# Altium schematic sheet 28Pins_Schematic_V1I1.SchDoc(28Pins_Schematic_V1I1)
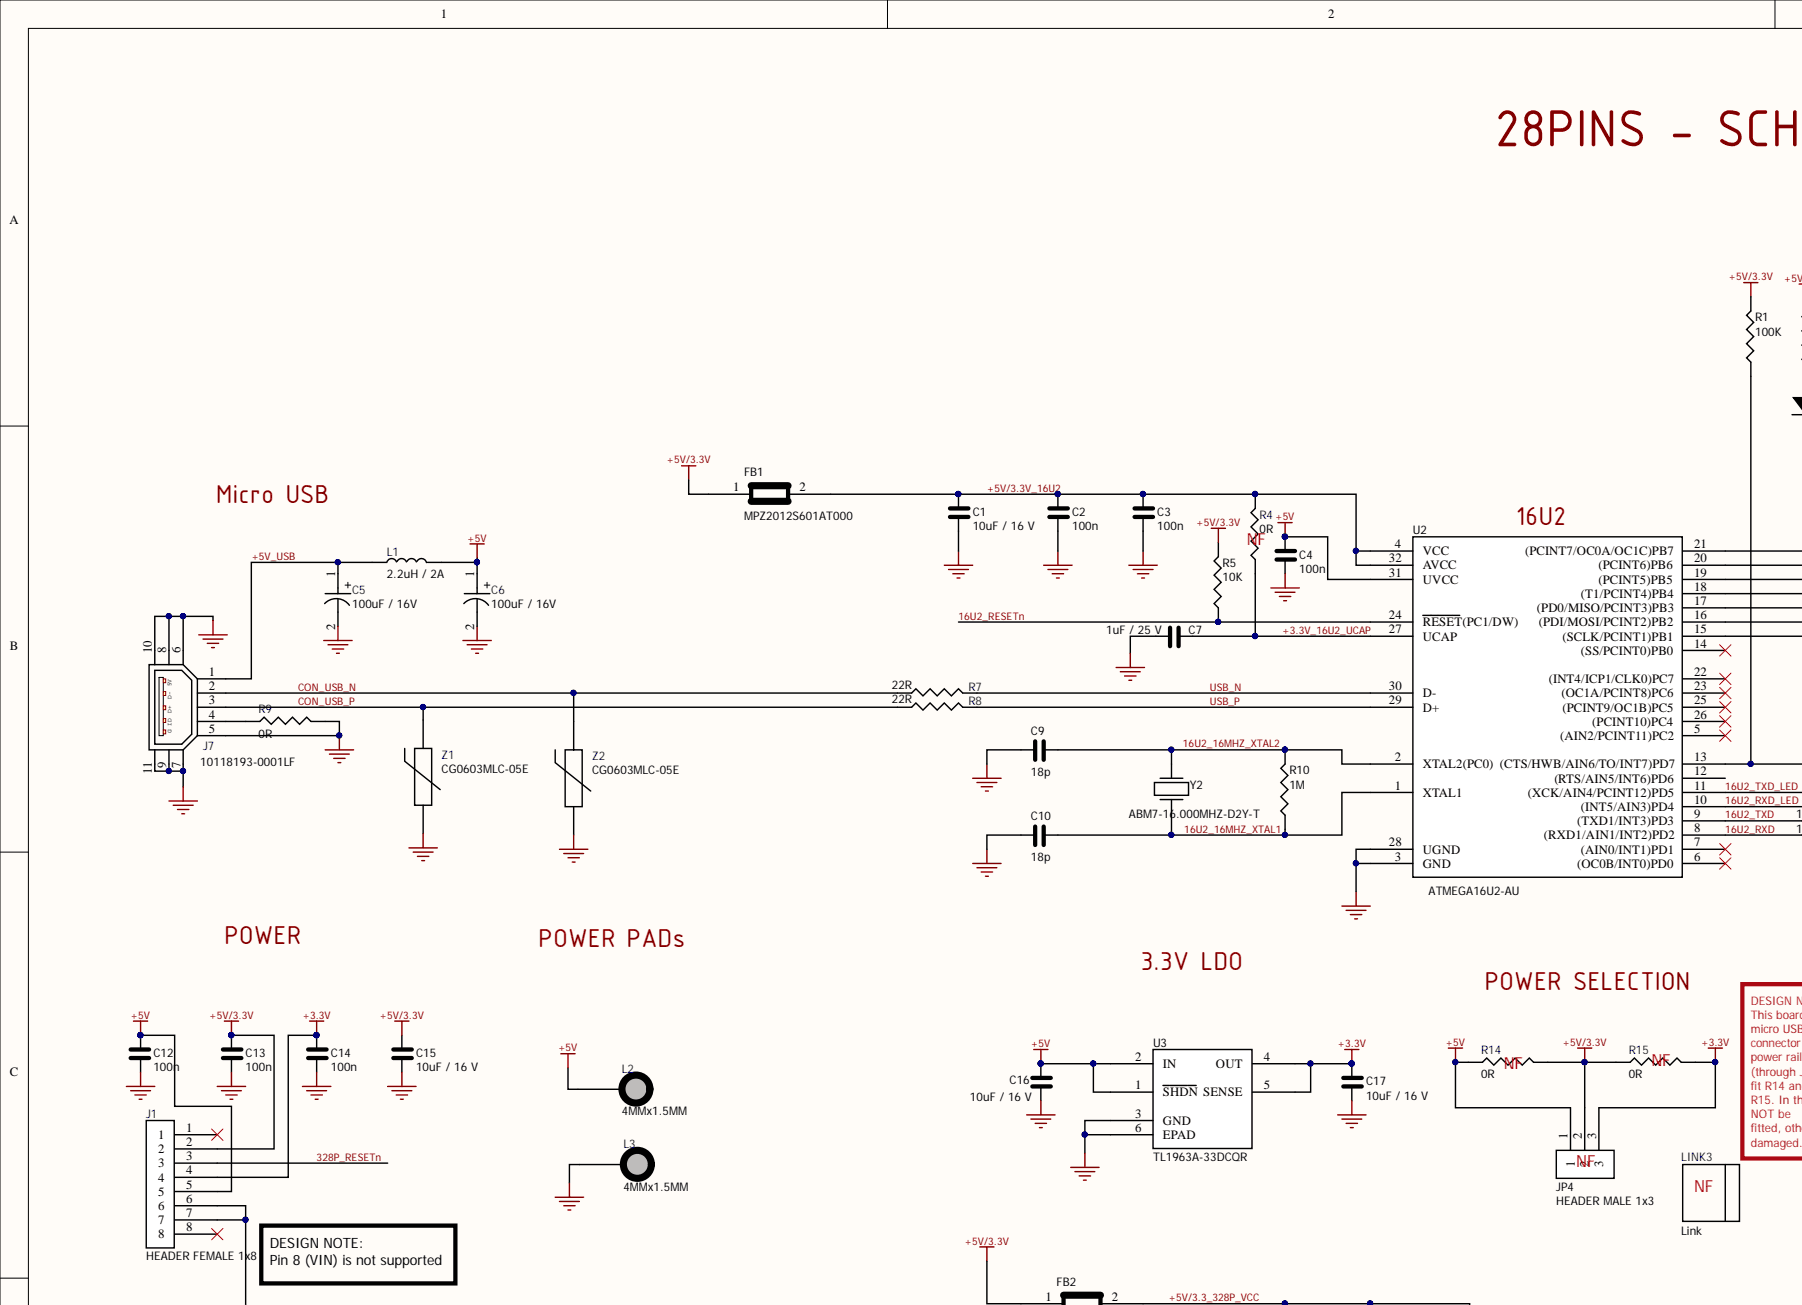
[diagram p0: 28Pins_Schematic_V1I1.SchDoc, top left]
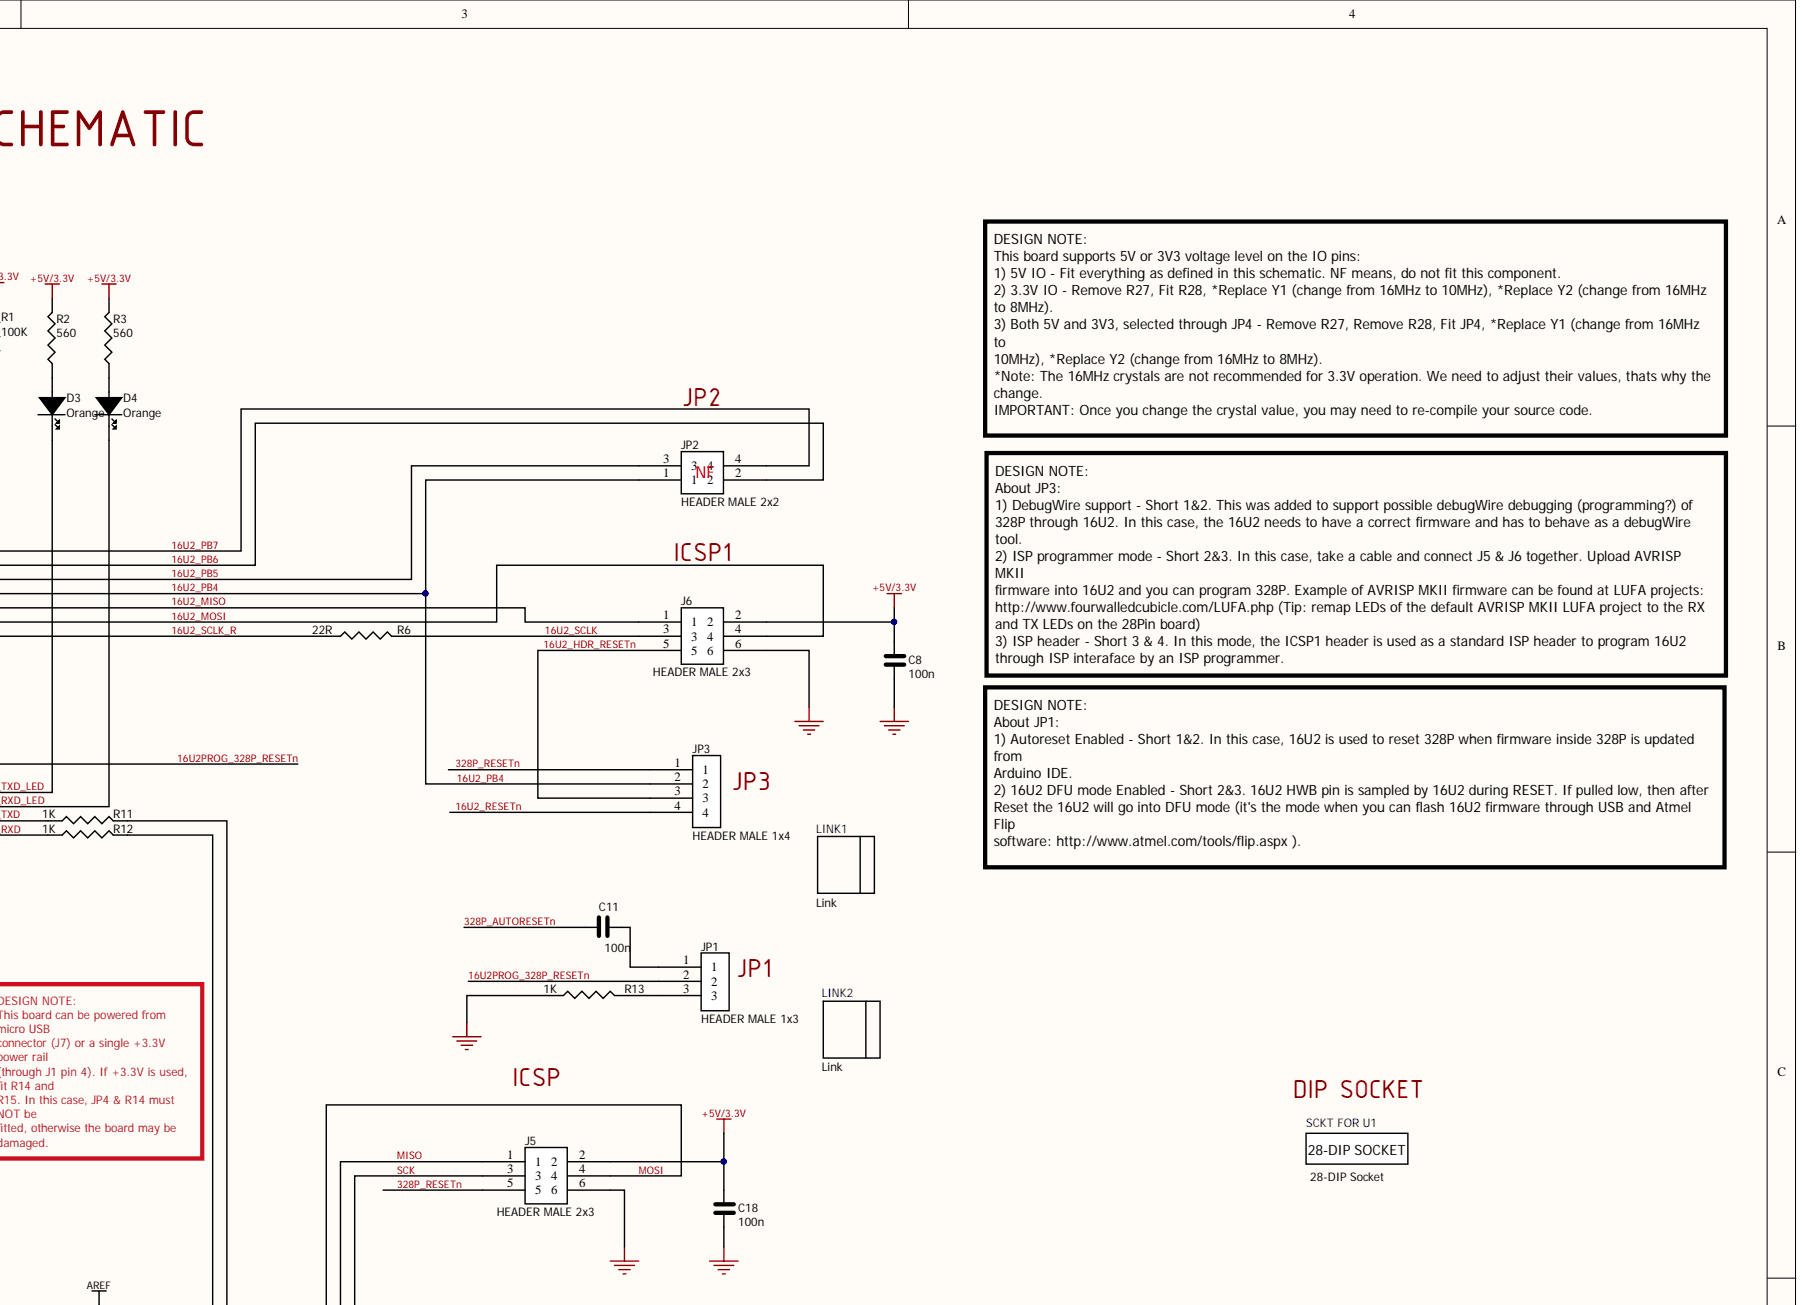
[diagram p1: 28Pins_Schematic_V1I1.SchDoc, top right]
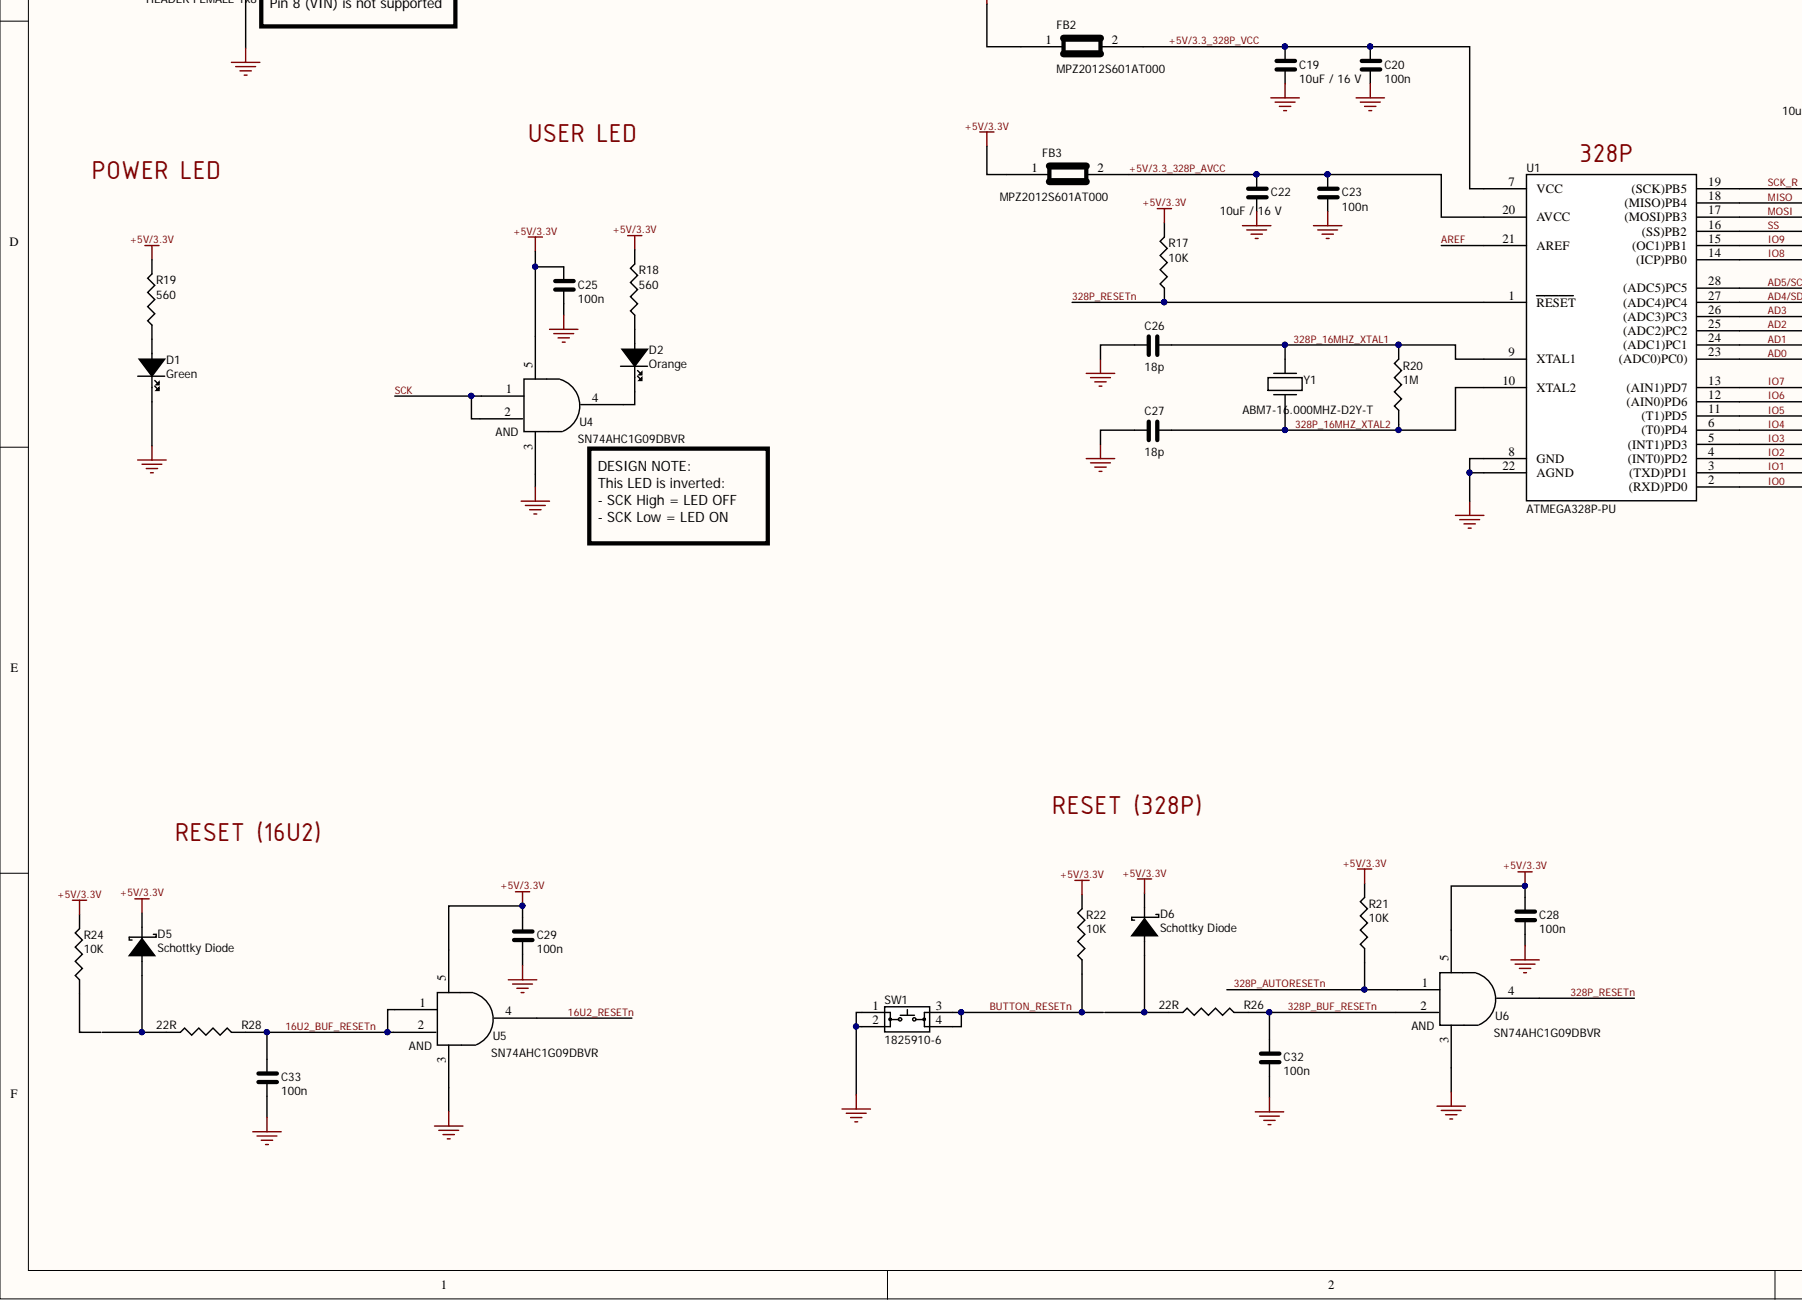
[diagram p2: 28Pins_Schematic_V1I1.SchDoc, bottom left]
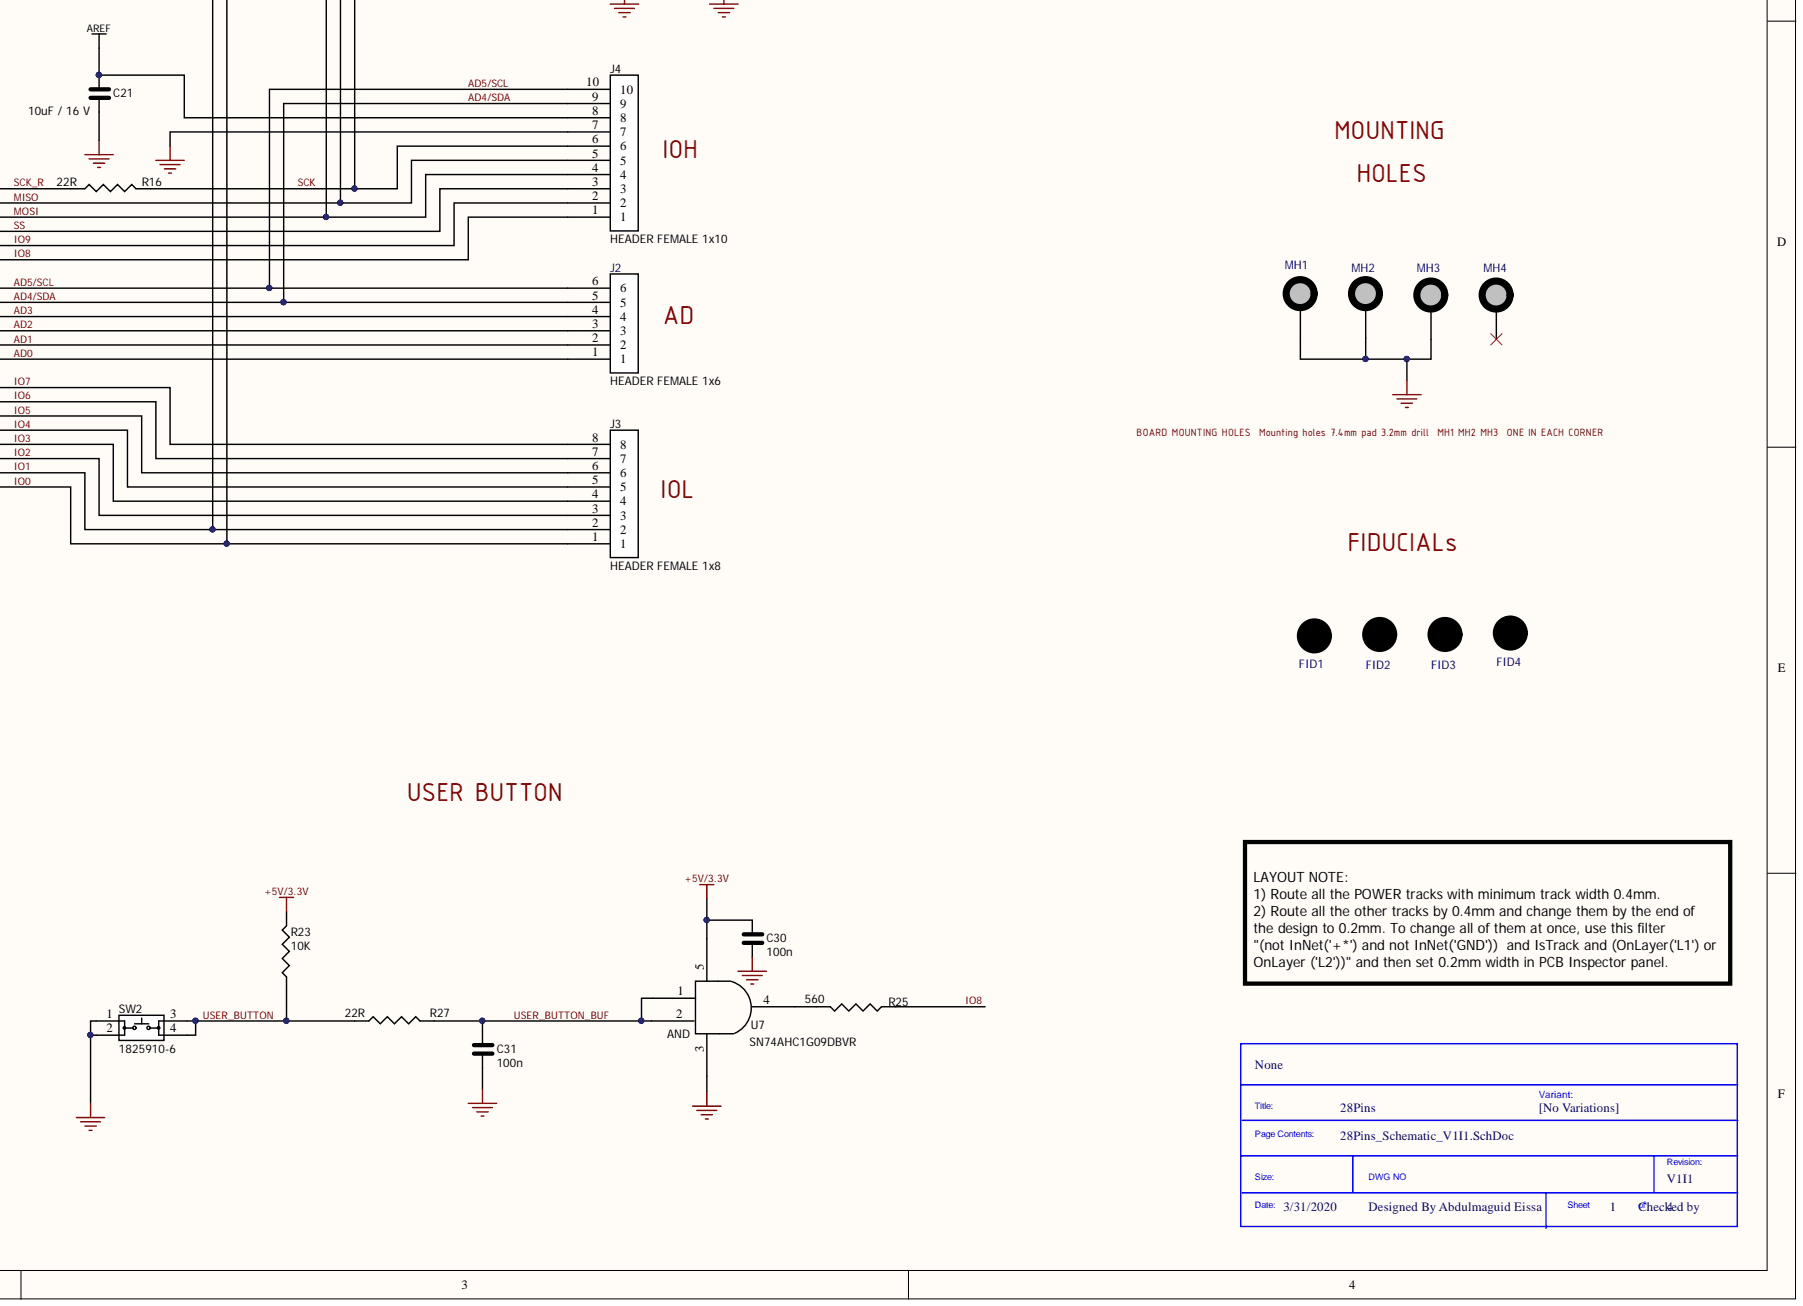
[diagram p3: 28Pins_Schematic_V1I1.SchDoc, bottom right]

## Parts
C1  10uF / 16 V  [C0805]
C2  100n  [C0805]
C3  100n  [C0805]
C4  100n  [C0805]
C5  100uF / 16V  [C_ALU_C_SIZE]
C6  100uF / 16V  [C_ALU_C_SIZE]
C7  1uF / 25 V  [C0805]
C8  100n  [C0805]
C9  18p  [C0805]
C10  18p  [C0805]
C11  100n  [C0805]
C12  100n  [C0805]
C13  100n  [C0805]
C14  100n  [C0805]
C15  10uF / 16 V  [C0805]
C16  10uF / 16 V  [C0805]
C17  10uF / 16 V  [C0805]
C18  100n  [C0805]
C19  10uF / 16 V  [C0805]
C20  100n  [C0805]
C21  10uF / 16 V  [C0805]
C22  10uF / 16 V  [C0805]
C23  100n  [C0805]
C25  100n  [C0805]
C26  18p  [C0805]
C27  18p  [C0805]
C28  100n  [C0805]
C29  100n  [C0805]
C30  100n  [C0805]
C31  100n  [C0805]
C32  100n  [C0805]
C33  100n  [C0805]
D1  Green  [LED0603]
D2  Orange  [LED0603]
D3  Orange  [LED0603]
D4  Orange  [LED0603]
D5  Schottky Diode  [SOD323]
D6  Schottky Diode  [SOD323]
FB1  MPZ2012S601AT000  [C0805]
FB2  MPZ2012S601AT000  [C0805]
FB3  MPZ2012S601AT000  [C0805]
FID1  FID_1mm  [FID_40x1120]  (value not drawn)  (no BOM)
FID2  FID_1mm  [FID_40x1120]  (value not drawn)  (no BOM)
FID3  FID_1mm  [FID_40x1120]  (value not drawn)  (no BOM)
FID4  FID_1mm  [FID_40x1120]  (value not drawn)  (no BOM)
J1  HEADER FEMALE 1x8  [1x8PIN_FEMALE_HDR]
J2  HEADER FEMALE 1x6  [1x6PIN_FEMALE_HDR]
J3  HEADER FEMALE 1x8  [1x8PIN_FEMALE_HDR]
J4  HEADER FEMALE 1x10  [1x10PIN_FEMALE_HDR]
J5  HEADER MALE 2x3  [2x3PIN_MALE_HDR]
J6  HEADER MALE 2x3  [2x3PIN_MALE_HDR]
J7  10118193-0001LF  [USB_SOP]
JP1  HEADER MALE 1x3  [1x3PIN_MALE_HDR]
JP2  HEADER MALE 2x2  [2x2PIN_MALE_HDR]
JP3  HEADER MALE 1x4  [1x4PIN_MALE_HDR]
JP4  HEADER MALE 1x3  [1x3PIN_MALE_HDR]
L1  2.2uH / 2A  [L1210]
L2  4MMx1.5MM  [PADS_WITH_WHOLE_4mmx1.5mm]  (no BOM)
L3  4MMx1.5MM  [PADS_WITH_WHOLE_4mmx1.5mm]  (no BOM)
LINK1  Link  (mechanical)
LINK2  Link  (mechanical)
LINK3  Link  (mechanical)
MH1  Mounting Whole 3.4mmx3.2mm  [MOUNTING_WHOLE_NON_COPPER]  (value not drawn)  (no BOM)
MH2  Mounting Whole 3.4mmx3.2mm  [MOUNTING_WHOLE_NON_COPPER]  (value not drawn)  (no BOM)
MH3  Mounting Whole 3.4mmx3.2mm  [MOUNTING_WHOLE_NON_COPPER]  (value not drawn)  (no BOM)
MH4  Mounting Whole 3.4mmx3.2mm  [MOUNTING_WHOLE_NON_COPPER]  (value not drawn)  (no BOM)
R1  100K  [R0805]
R2  560  [R0805]
R3  560  [R0805]
R4  0R  [R0805]
R5  10K  [R0805]
R6  22R  [R0805]
R7  22R  [R0805]
R8  22R  [R0805]
R9  0R  [R0805]
R10  1M  [R0805]
R11  1K  [R0805]
R12  1K  [R0805]
R13  1K  [R0805]
R14  0R  [R0805]
R15  0R  [R0805]
R16  22R  [R0805]
R17  10K  [R0805]
R18  560  [R0805]
R19  560  [R0805]
R20  1M  [R0805]
R21  10K  [R0805]
R22  10K  [R0805]
R23  10K  [R0805]
R24  10K  [R0805]
R25  560  [R0805]
R26  22R  [R0805]
R27  22R  [R0805]
R28  22R  [R0805]
SCKT_FOR_U1  28-DIP Socket  [28-DIP_SCKT]
SW1  1825910-6  [4PIN_BUTTON]
SW2  1825910-6  [4PIN_BUTTON]
U1  ATMEGA328P-PU  [28_DIP_U1]
U2  ATMEGA16U2-AU  [TQFP32]
U3  TL1963A-33DCQR  [DCQR R-PDSO-G6]
U4  SN74AHC1G09DBVR  [DBV_SOP_23_5]
U5  SN74AHC1G09DBVR  [DBV_SOP_23_5]
U6  SN74AHC1G09DBVR  [DBV_SOP_23_5]
U7  SN74AHC1G09DBVR  [DBV_SOP_23_5]
Y1  ABM7-16.000MHZ-D2Y-T  [AMB7_V1]
Y2  ABM7-16.000MHZ-D2Y-T  [AMB7_V1]
Z1  CG0603MLC-05E  [R0603]
Z2  CG0603MLC-05E  [R0603]

## Nets
+3.3V: C14.2 C17.2 J1.4 JP4.3 R15.2 U3.4(OUT) U3.5(SENSE)
+5V: C4.2 C6.1 C12.1 C16.2 J1.5 JP4.1 L1.2 L2.1 R14.1 U2.31(UVCC) U3.1(SHDN) U3.2(IN)
+5V/3.3V: C8.2 C13.2 C15.2 C18.2 C25.2 C28.2 C29.2 C30.2 D5.1 D6.1 FB1.1 FB2.1 FB3.1 J1.2 J5.2 J6.2 JP4.2 R1.2 R2.2 R3.2 R5.2 R14.2 R15.1 R17.2 R18.2 R19.2 R21.2 R22.2 R23.2 R24.2 U4.5 U5.5 U6.5 U7.5
GND: C1.1 C2.1 C3.1 C4.1 C5.2 C6.2 C7.1 C8.1 C9.1 C10.1 C12.2 C13.1 C14.1 C15.1 C16.1 C17.1 C18.1 C19.1 C20.1 C21.1 C22.1 C23.1 C25.1 C26.1 C27.1 C28.1 C29.1 C30.1 C31.1 C32.1 C33.1 D1.1 J1.6 J1.7 J4.7 J5.6 J6.6 J7.5 J7.6 J7.7 J7.8(5V) J7.9 J7.10 J7.11 L3.1 MH1.1 MH2.1 MH3.1 R9.2 R13.1 SW1.1 SW1.2 SW2.1 SW2.2 U1.8(GND) U1.22(AGND) U2.3(GND) U2.28(UGND) U3.3(GND) U3.6(EPAD) U4.3 U5.3 U6.3 U7.3 Z1.1 Z2.1
16U2_16MHZ_XTAL1: C10.2 R10.1 U2.1(XTAL1) Y2.1
16U2_16MHZ_XTAL2: C9.2 R10.2 U2.2(XTAL2(PC0)) Y2.2
16U2_BUF_RESETN: C33.2 R28.2 U5.1 U5.2
16U2_HDR_RESETN: J6.5 JP3.3
16U2_MISO: J6.1 U2.17((PD0/MISO/PCINT3)PB3)
16U2_MOSI: J6.4 U2.16((PDI/MOSI/PCINT2)PB2)
16U2_PB4: JP2.1 JP3.2 U2.18((T1/PCINT4)PB4)
16U2_PB5: JP2.3 U2.19((PCINT5)PB5)
16U2_PB6: JP2.2(NF) U2.20((PCINT6)PB6)
16U2_PB7: JP2.4 U2.21((PCINT7/OC0A/OC1C)PB7)
16U2_RESETN: JP3.4 R5.1 U2.24(RESET(PC1/DW)) U5.4
16U2_RXD: R12.1 U2.8((RXD1/AIN1/INT2)PD2)
16U2_RXD_LED: D4.1 U2.10((INT5/AIN3)PD4)
16U2_SCLK: J6.3 R6.2
16U2_SCLK_R: R6.1 U2.15((SCLK/PCINT1)PB1)
16U2_TXD: R11.1 U2.9((TXD1/INT3)PD3)
16U2_TXD_LED: D3.1 U2.11((XCK/AIN4/PCINT12)PD5)
16U2PROG_328P_RESETN: JP1.2 R1.1 U2.13((CTS/HWB/AIN6/TO/INT7)PD7)
328P_16MHZ_XTAL1: C26.2 R20.2 U1.9(XTAL1) Y1.2
328P_16MHZ_XTAL2: C27.2 R20.1 U1.10(XTAL2) Y1.1
328P_AUTORESETN: C11.2 R21.1 U6.1
328P_BUF_RESETN: C32.2 R26.2 U6.2
328P_RESETN: J1.3 J5.5 JP3.1 R17.1 U1.1(RESET) U6.4
+3.3V_16U2_UCAP: C7.2 R4.1 U2.27(UCAP)
+5V/3.3_328P_AVCC: C22.2 C23.2 FB3.2 U1.20(AVCC)
+5V/3.3_328P_VCC: C19.2 C20.2 FB2.2 U1.7(VCC)
+5V/3.3V_16U2: C1.2 C2.2 C3.2 FB1.2 R4.2 U2.4(VCC) U2.32(AVCC)
+5V_USB: C5.1 J7.1 L1.1
AD0: J2.1 U1.23((ADC0)PC0))
AD1: J2.2 U1.24((ADC1)PC1)
AD2: J2.3 U1.25((ADC2)PC2)
AD3: J2.4 U1.26((ADC3)PC3)
AD4/SDA: J2.5 J4.9 U1.27((ADC4)PC4)
AD5/SCL: J2.6 J4.10 U1.28((ADC5)PC5)
AREF: C21.2 J4.8 U1.21(AREF)
BUTTON_RESETN: R22.1 SW1.3 SW1.4
CON_USB_N: J7.2 R7.1 Z2.2
CON_USB_P: J7.3 R8.1 Z1.2
IO0: J3.1 R11.2 U1.2((RXD)PD0)
IO1: J3.2 R12.2 U1.3((TXD)PD1)
IO2: J3.3 U1.4((INT0)PD2)
IO3: J3.4 U1.5((INT1)PD3)
IO4: J3.5 U1.6((T0)PD4)
IO5: J3.6 U1.11((T1)PD5)
IO6: J3.7 U1.12((AIN0)PD6)
IO7: J3.8 U1.13((AIN1)PD7)
IO8: J4.1 R25.1 U1.14((ICP)PB0)
IO9: J4.2 U1.15((OC1)PB1)
MISO: J4.5 J5.1 U1.18((MISO)PB4)
MOSI: J4.4 J5.4 U1.17((MOSI)PB3)
SCK: J4.6 J5.3 R16.2 U4.1 U4.2
SCK_R: R16.1 U1.19((SCK)PB5)
SS: J4.3 U1.16((SS)PB2)
USB_N: R7.2 U2.30(D-)
USB_P: R8.2 U2.29(D+)
USER_BUTTON: R23.1 R27.1 SW2.3 SW2.4
USER_BUTTON_BUF: C31.2 R27.2 U7.1 U7.2
NetC11_1: C11.1 JP1.1
NetD1_2: D1.2 R19.1
NetD2_1: D2.1 U4.4
NetD2_2: D2.2 R18.1
NetD3_2: D3.2 R2.1
NetD4_2: D4.2 R3.1
NetD5_2: D5.2 R28.1
NetD6_2: D6.2 R26.1
NetJ7_4: J7.4 R9.1
NetJP1_3: JP1.3 R13.2
NetR25_2: R25.2 U7.4

Not connected: J1 1 8; MH4 1; R24 1; U2 5((AIN2/PCINT11)PC2) 6((OC0B/INT0)PD0) 7((AIN0/INT1)PD1) 12((RTS/AIN5/INT6)PD6) 14((SS/PCINT0)PB0) 22((INT4/ICP1/CLK0)PC7) 23((OC1A/PCINT8)PC6) 25((PCINT9/OC1B)PC5) 26((PCINT10)PC4)

## Library data of the parts
C1 C15-C17 C19 C21 C22 = 10uF_CAP: Component Kind: Standard; Library Name: 28Pins_Schematic_Lib.SchLib
C2-C4 C8 C11-C14 C18 C20 C23 C25 C28-C33 = 0.1uF_CAP: Component Kind: Standard; Library Name: 28Pins_Schematic_Lib.SchLib
C5 C6 = 100uF_PCAP: Component Kind: Standard; Library Name: 28Pins_Schematic_Lib.SchLib
C7 = 1uF_CAP: Component Kind: Standard; Library Name: 28Pins_Schematic_Lib.SchLib
C9 C10 C26 C27 = 18pF_CAP: Component Kind: Standard; Library Name: 28Pins_Schematic_Lib.SchLib
D1 = LED_Green: Component Kind: Standard; Library Name: 28Pins_Schematic_Lib.SchLib
D2-D4 = LED_Orange: Component Kind: Standard; Library Name: 28Pins_Schematic_Lib.SchLib
D5 D6 = Schottky Diode: Diode,Schottky Barrier,Fast,Vr 30V,If 200mA,Pkg SOD-323,Vf 0.52VDC,Trr 5ns; Manufacturer: ON Semiconductor; Manufacturer Part Number: BAT54HT1G; Component Kind: Standard; ComponentLink1Description: https://www.alliedelec.com/m/d/32ed7fc37a0b4f97ae8b34bd526f7e4f.pdf; ComponentLink1URL: https://www.alliedelec.com/m/d/32ed7fc37a0b4f97ae8b34bd526f7e4f.pdf; ComponentLink2Description: https://www.alliedelec.com/onsemiconductor-bat54ht1g/70099482/; ComponentLink2URL: https://www.alliedelec.com/onsemiconductor-bat54ht1g/70099482/; Library Name: 28Pins_Schematic_Lib.SchLib; Pricing 1: 10=0.0946, 100=0.0936, 250=0.0927 (USD); RoHS: No; Stock 1: 4340; Supplier 1: Allied; Supplier Part Number 1: 70099482
FB1-FB3 = Ferrite_Bead: Component Kind: Standard; Library Name: 28Pins_Schematic_Lib.SchLib
FID1-FID4 = Fiducial: Fiducial 40mil diameter; Library Name: 28Pins_Schematic_Lib.SchLib
J1 J3 = 1x8Pin_Female_Hdr: Component Kind: Standard; Library Name: 28Pins_Schematic_Lib.SchLib
J2 = 1x6Pin_Female_Hdr: Component Kind: Standard; Library Name: 28Pins_Schematic_Lib.SchLib
J4 = 10Pin_Female_Hdr: Component Kind: Standard; Library Name: 28Pins_Schematic_Lib.SchLib
J5 J6 = 2x3Pin_Male_Hdr: Component Kind: Standard; Library Name: 28Pins_Schematic_Lib.SchLib
J7 = 10118193-0001LF: Right Angle Surface Mount Mount Female USB Connector 100 V ac 1.8A; Manufacturer: Amphenol ICC; Component Kind: Standard; ComponentLink1Description: https://www.alliedelec.com/m/d/e03afc8e486ea55a2b0205fb8203b916.pdf; ComponentLink1URL: https://www.alliedelec.com/m/d/e03afc8e486ea55a2b0205fb8203b916.pdf; ComponentLink2Description: https://www.alliedelec.com/amphenolicc-10118193-0001lf/70236827/; ComponentLink2URL: https://www.alliedelec.com/amphenolicc-10118193-0001lf/70236827/; Library Name: 28Pins_Schematic_Lib.SchLib; Manufacturer Part Number: 10118193-0001LF; Pricing 1: 1=0.2919, 25=0.2747, 100=0.2661, 250=0.2318, 500=0.2276 (USD); RoHS: No; Stock 1: 6540; Supplier 1: Allied; Supplier Part Number 1: 70236827
JP1 JP4 = 1x3Pin_Male_Hdr: Component Kind: Standard; Library Name: 28Pins_Schematic_Lib.SchLib
JP2 = 2x2Pin_Male_Hdr: Component Kind: Standard; Library Name: 28Pins_Schematic_Lib.SchLib
JP3 = 1x4Pin_Male_Hdr: Component Kind: Standard; Library Name: 28Pins_Schematic_Lib.SchLib
L1 = L1210R2R2MDWIT: Inductor; Component Kind: Standard; Library Name: 28Pins_Schematic_Lib.SchLib
L2 L3 = PAD_WITH_WHOLE_4MMx1.5MM: Library Name: 28Pins_Schematic_Lib.SchLib
LINK1-LINK3 = Link: CONN JUMPER; Library Name: 28Pins_Schematic_Lib.SchLib
MH1-MH4 = MOUNTING_WHOLE_NON_COPPER: Library Name: 28Pins_Schematic_Lib.SchLib
R1 = 100K_Resistor: Component Kind: Standard; Library Name: 28Pins_Schematic_Lib.SchLib
R2 R3 R18 R19 R25 = 560_Resistor: Component Kind: Standard; Library Name: 28Pins_Schematic_Lib.SchLib
R4 R9 R14 R15 = 0R_Resistor: Component Kind: Standard; Library Name: 28Pins_Schematic_Lib.SchLib
R5 R17 R21-R24 = 10K_Resistor: Component Kind: Standard; Library Name: 28Pins_Schematic_Lib.SchLib
R6-R8 R16 R26-R28 = 22_Resistor: Component Kind: Standard; Library Name: 28Pins_Schematic_Lib.SchLib
R10 R20 = 1M_Resistor: Component Kind: Standard; Library Name: 28Pins_Schematic_Lib.SchLib
R11-R13 = 1K_Resistor: Component Kind: Standard; Library Name: 28Pins_Schematic_Lib.SchLib
SCKT_FOR_U1 = 28Pin_DIP: Component Kind: Standard; Library Name: 28Pins_Schematic_Lib.SchLib
SW1 SW2 = 4Pin_Push_Button: Push_Button; Component Kind: Standard; Library Name: 28Pins_Schematic_Lib.SchLib
U1 = ATMEGA328P-PU: ATMEGA328P-PU; 8 bit Microcontroller AVR 20MHz 1; 32kb Flash; 2kb RAM; I2C SPI 2; Manufacturer: Microchip Technology Inc.; Component Kind: Standard; ComponentLink1Description: https://www.alliedelec.com/m/d/768ce6a229d36838fb8bd4dcb29bd26e.pdf; ComponentLink1URL: https://www.alliedelec.com/m/d/768ce6a229d36838fb8bd4dcb29bd26e.pdf; ComponentLink2Description: https://www.alliedelec.com/microchiptechnologyinc-atmega328p-pu/70332157/; ComponentLink2URL: https://www.alliedelec.com/microchiptechnologyinc-atmega328p-pu/70332157/; Library Name: 28Pins_Schematic_Lib.SchLib; Manufacturer Part Number: ATMEGA328P-PU; Pricing 1: 1=5.1200, 5=4.6100, 10=4.1800, 25=3.8300, 100=3.5500 (USD); RoHS: No; Stock 1: 0; Supplier 1: Allied; Supplier Part Number 1: 70332157
U3 = Regulator: Component Kind: Standard; Library Name: 28Pins_Schematic_Lib.SchLib
U4-U7 = AND gate: Component Kind: Standard; Library Name: 28Pins_Schematic_Lib.SchLib
Y1 Y2 = ABM7-16.000MHZ-D2Y-T: Crystal; Ceramic; 16.000MHZ; 18pf; 20ppm @ 25C; 30ppm -40+85C; 6.0 x 3.5 x 1.2mm; T/R; Manufacturer: Abracon; Component Kind: Standard; ComponentLink1Description: https://www.alliedelec.com; ComponentLink1URL: https://www.alliedelec.com; ComponentLink2Description: https://www.alliedelec.com/abracon-abm7-16-000mhz-d2y-t/71528095/; ComponentLink2URL: https://www.alliedelec.com/abracon-abm7-16-000mhz-d2y-t/71528095/; Library Name: 28Pins_Schematic_Lib.SchLib; Manufacturer Part Number: ABM7-16.000MHZ-D2Y-T; Pricing 1: 1000=0.5800 (USD); RoHS: No; Stock 1: 0; Supplier 1: Allied; Supplier Part Number 1: 71528095
Z1 Z2 = CG0603MLC-05E: Varistor; ESD Clamp Protector; 5 VDC; 20 Vclamp; IEC 61000-4-2 Level 4; 0603 SMD; Manufacturer: Bourns; Component Kind: Standard; ComponentLink1Description: https://www.alliedelec.com/m/d/c74d21cd372fe06fa9c5b8b4ec8a7246.pdf; ComponentLink1URL: https://www.alliedelec.com/m/d/c74d21cd372fe06fa9c5b8b4ec8a7246.pdf; ComponentLink2Description: https://www.alliedelec.com/bourns-cg0603mlc-05e/70153503/; ComponentLink2URL: https://www.alliedelec.com/bourns-cg0603mlc-05e/70153503/; Library Name: 28Pins_Schematic_Lib.SchLib; Manufacturer Part Number: CG0603MLC-05E; Pricing 1: 1=0.1100, 1250=0.1067, 2500=0.1012, 12500=0.0946, 25000=0.0869, 125000=0.0682 (USD); RoHS: No; Stock 1: 7999; Supplier 1: Allied; Supplier Part Number 1: 70153503
U2 = ATMEGA16U2-AU: Component Kind: Standard; Library Name: 28Pins_Schematic_Lib.SchLib
